# Altium schematic sheet RF_Front-End2.SchDoc
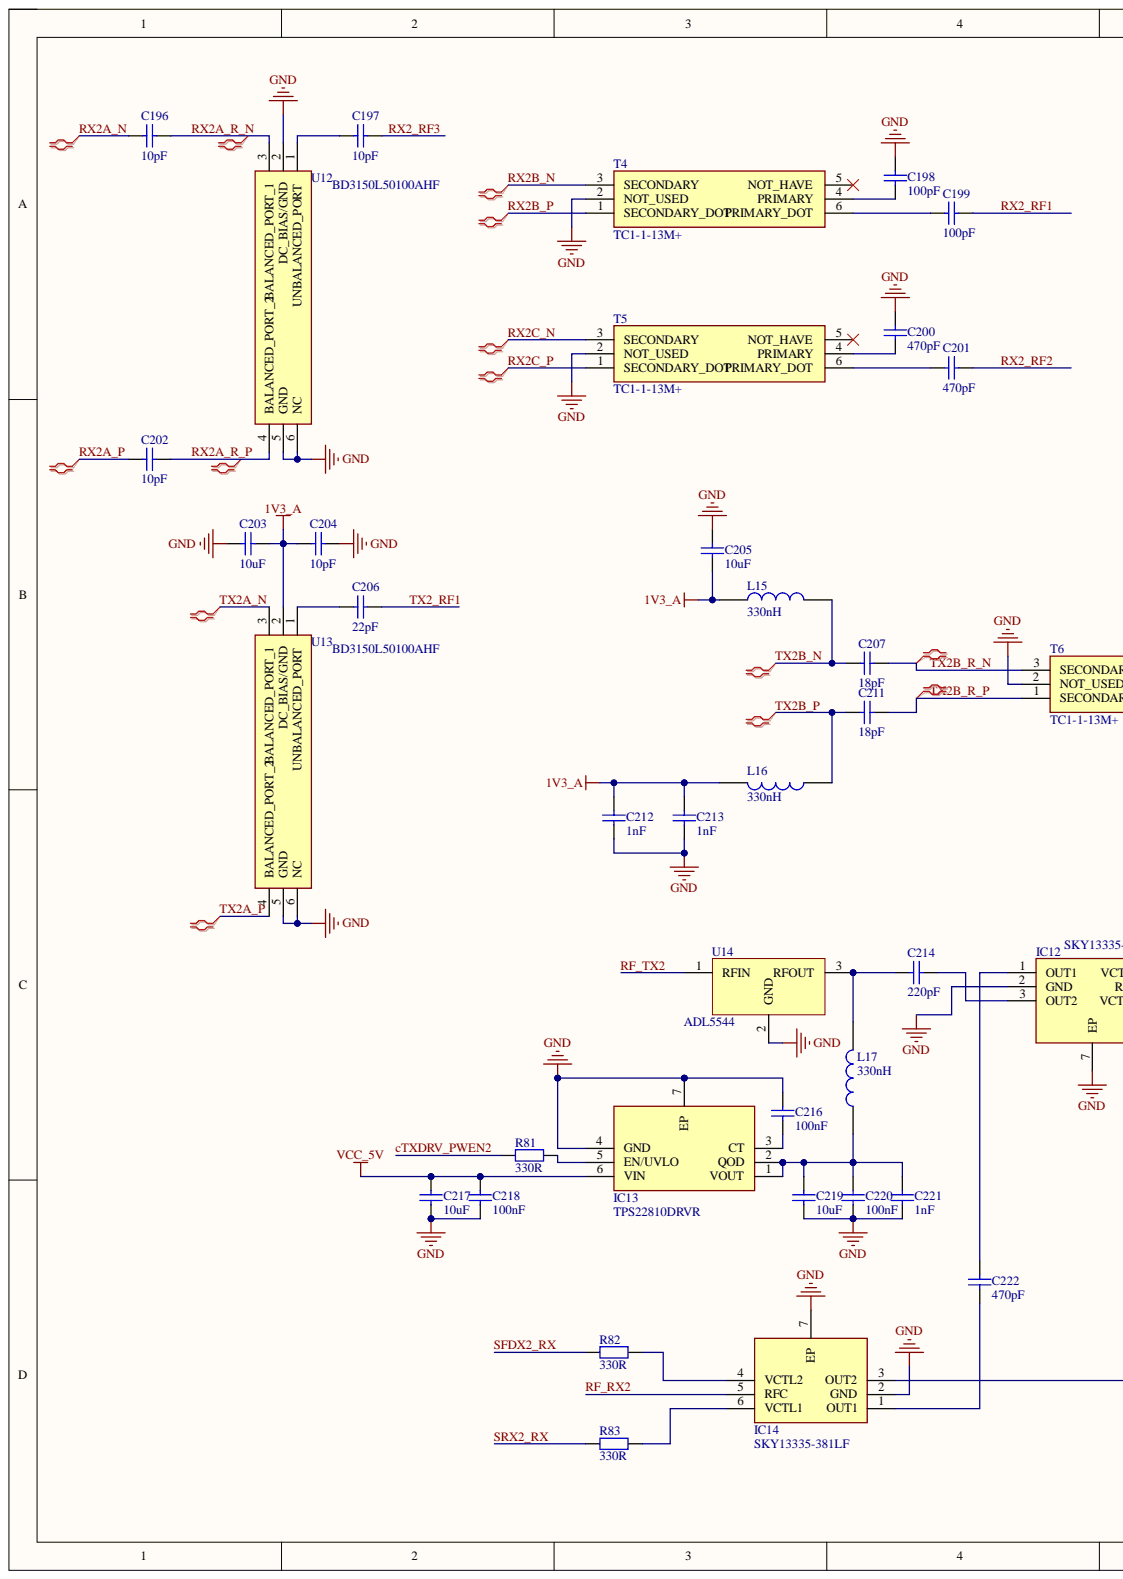
[diagram p0: RF_Front-End2.SchDoc, left]
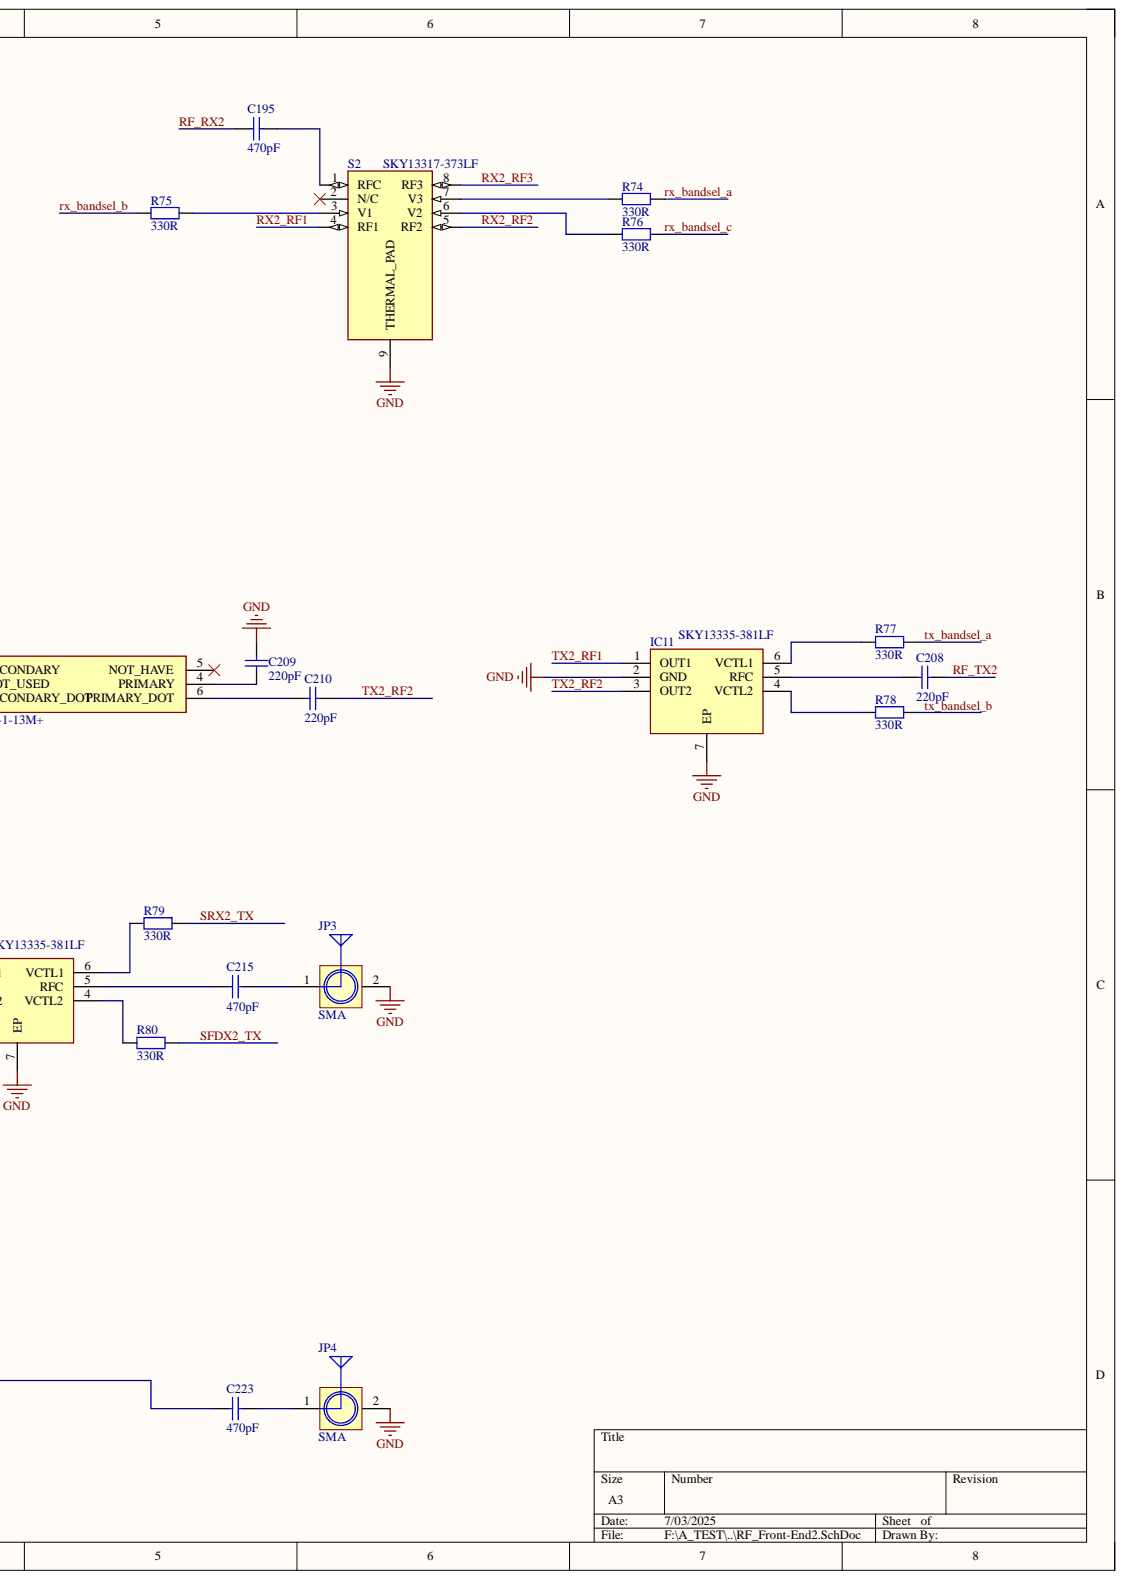
[diagram p1: RF_Front-End2.SchDoc, right]

## Parts
C195  470pF  [C 0402_L]
C196  10pF  [C 0402_L]
C197  10pF  [C 0402_L]
C198  100pF  [C 0402_L]
C199  100pF  [C 0402_L]
C200  470pF  [C 0402_L]
C201  470pF  [C 0402_L]
C202  10pF  [C 0402_L]
C203  10uF  [C 0402_L]
C204  10pF  [C 0402_L]
C205  10uF  [C 0402_L]
C206  22pF  [C 0402_L]
C207  18pF  [C 0402_L]
C208  220pF  [C 0402_L]
C209  220pF  [C 0402_L]
C210  220pF  [C 0402_L]
C211  18pF  [C 0402_L]
C212  1nF  [C 0402_L]
C213  1nF  [C 0402_L]
C214  220pF  [C 0402_L]
C215  470pF  [C 0402_L]
C216  100nF  [C 0402_L]
C217  10uF  [C 0603_L]
C218  100nF  [C 0402_L]
C219  10uF  [C 0603_L]
C220  100nF  [C 0402_L]
C221  1nF  [C 0402_L]
C222  470pF  [C 0402_L]
C223  470pF  [C 0402_L]
IC11  SKY13335-381LF  [SON50P200X150X50-7N]
IC12  SKY13335-381LF  [SON50P200X150X50-7N]
IC13  TPS22810DRVR  [SON65P200X200X80-7N]
IC14  SKY13335-381LF  [SON50P200X150X50-7N]
JP3  SMA  [SMA-KE - 0.5mm]
JP4  SMA  [SMA-KE - 0.5mm]
L15  330nH  [L 0402]
L16  330nH  [L 0402]
L17  330nH  [L 0603]
R74  330R  [R 0402_L]
R75  330R  [R 0402_L]
R76  330R  [R 0402_L]
R77  330R  [R 0402_L]
R78  330R  [R 0402_L]
R79  330R  [R 0402_L]
R80  330R  [R 0402_L]
R81  330R  [R 0402_L]
R82  330R  [R 0402_L]
R83  330R  [R 0402_L]
S2  SKY13317-373LF  [SON40P155X155X50-9N]
T4  TC1-1-13M+  [TC414]
T5  TC1-1-13M+  [TC414]
T6  TC1-1-13M+  [TC414]
U12  BD3150L50100AHF  [BD3150L50100AHF]
U13  BD3150L50100AHF  [BD3150L50100AHF]
U14  ADL5544  [SOT89]

## Nets
1V3_A: C203.1 C204.2 C205.1 C212.1 C213.1 L15.1 L16.2 U13.2(DC_BIAS/GND)
GND: C198.1 C200.1 C203.2 C204.1 C205.2 C209.1 C212.2 C213.2 C216.2 C217.1 C218.1 C219.1 C220.1 C221.1 IC11.2(GND) IC11.7(EP) IC12.2(GND) IC12.7(EP) IC13.4(GND) IC13.7(EP) IC14.2(GND) IC14.7(EP) JP3.2 JP4.2 S2.9(THERMAL_PAD) T4.2(NOT_USED) T5.2(NOT_USED) T6.2(NOT_USED) U12.2(DC_BIAS/GND) U12.5(GND) U12.6(NC) U13.5(GND) U13.6(NC) U14.2
VCC_5V: C217.2 C218.2 IC13.6(VIN)
cTXDRV_PWEN2: R81.1
RF_RX2: C195.1 IC14.5(RFC)
RF_TX2: C208.1 U14.1
RX2_RF1: C199.2 S2.4(RF1)
RX2_RF2: C201.2 S2.5(RF2)
RX2_RF3: C197.1 S2.8(RF3)
RX2A_N: C196.2
RX2A_P: C202.2
RX2A_R_N: C196.1 U12.3(BALANCED_PORT_1)
RX2A_R_P: C202.1 U12.4(BALANCED_PORT_2)
RX2B_N: T4.3(SECONDARY)
RX2B_P: T4.1(SECONDARY_DOT)
RX2C_N: T5.3(SECONDARY)
RX2C_P: T5.1(SECONDARY_DOT)
rx_bandsel_a: R74.2
rx_bandsel_b: R75.1
rx_bandsel_c: R76.2
SFDX2_RX: R82.1
SFDX2_TX: R80.2
SRX2_RX: R83.1
SRX2_TX: R79.2
TX2_RF1: C206.1 IC11.1(OUT1)
TX2_RF2: C210.2 IC11.3(OUT2)
TX2A_N: U13.3(BALANCED_PORT_1)
TX2A_P: U13.4(BALANCED_PORT_2)
TX2B_N: C207.1 L15.2
TX2B_P: C211.1 L16.1
TX2B_R_N: C207.2 T6.3(SECONDARY)
TX2B_R_P: C211.2 T6.1(SECONDARY_DOT)
tx_bandsel_a: R77.2
tx_bandsel_b: R78.2
NetC195_2: C195.2 S2.1(RFC)
NetC197_2: C197.2 U12.1(UNBALANCED_PORT)
NetC198_2: C198.2 T4.4(PRIMARY)
NetC199_1: C199.1 T4.6(PRIMARY_DOT)
NetC200_2: C200.2 T5.4(PRIMARY)
NetC201_1: C201.1 T5.6(PRIMARY_DOT)
NetC206_2: C206.2 U13.1(UNBALANCED_PORT)
NetC208_2: C208.2 IC11.5(RFC)
NetC209_2: C209.2 T6.4(PRIMARY)
NetC210_1: C210.1 T6.6(PRIMARY_DOT)
NetC214_1: C214.1 IC12.3(OUT2)
NetC214_2: C214.2 L17.2 U14.3
NetC215_1: C215.1 JP3.1
NetC215_2: C215.2 IC12.5(RFC)
NetC216_1: C216.1 IC13.3(CT)
NetC219_2: C219.2 C220.2 C221.2 IC13.1(VOUT) IC13.2(QOD) L17.1
NetC222_1: C222.1 IC12.1(OUT1)
NetC222_2: C222.2 IC14.1(OUT1)
NetC223_1: C223.1 JP4.1
NetC223_2: C223.2 IC14.3(OUT2)
NetIC11_4: IC11.4(VCTL2) R78.1
NetIC11_6: IC11.6(VCTL1) R77.1
NetIC12_4: IC12.4(VCTL2) R80.1
NetIC12_6: IC12.6(VCTL1) R79.1
NetIC13_5: IC13.5(EN/UVLO) R81.2
NetIC14_4: IC14.4(VCTL2) R82.2
NetIC14_6: IC14.6(VCTL1) R83.2
NetR74_1: R74.1 S2.7(V3)
NetR75_2: R75.2 S2.3(V1)
NetR76_1: R76.1 S2.6(V2)

Not connected: S2 2(N/C); T4 5(NOT_HAVE); T5 5(NOT_HAVE); T6 5(NOT_HAVE)

## Library data of the parts
C195-C223 = Cap: 贴片电容; Component Kind: Standard; Library Name: A_Schlib.SchLib
  C195 C200 C201 C215 C222 C223: value: 470pF
  C196 C197 C202 C204: value: 10pF
  C198 C199: value: 100pF
  C203 C205 C217 C219: value: 10uF
  C206: value: 22pF
  C207 C211: value: 18pF
  C208-C210 C214: value: 220pF
  C212 C213 C221: value: 1nF
  C216 C218 C220: value: 100nF
IC11 IC12 IC14 = SKY13335-381LF: Integrated Circuit; Manufacturer_Name: Skyworks; Component Kind: Standard; Datasheet Link: https://eu.mouser.com/datasheet/2/472/SKY13335_381LF_201093D-1524822.pdf; Height: 0.5mm; Library Name: SamacSys.SchLib; Manufacturer_Part_Number: SKY13335-381LF; Mouser Part Number: 873-SKY13335-381LF; Mouser Price/Stock: https://www.mouser.co.uk/ProductDetail/Skyworks-Solutions-Inc/SKY13335-381LF?qs=WMHGlxXAKT8o8eJW4DHjzg%3D%3D
IC13 = TPS22810DRVR: Integrated Circuit; Manufacturer_Name: Texas Instruments; Arrow Part Number: TPS22810DRVR; Arrow Price/Stock: https://www.arrow.com/en/products/tps22810drvr/texas-instruments?region=nac; Component Kind: Standard; Datasheet Link: http://www.ti.com/lit/gpn/tps22810; Height: 0.8mm; Library Name: SamacSys.SchLib; Manufacturer_Part_Number: TPS22810DRVR; Mouser Part Number: 595-TPS22810DRVR; Mouser Price/Stock: https://www.mouser.co.uk/ProductDetail/Texas-Instruments/TPS22810DRVR?qs=5aG0NVq1C4wkfnc1%252B6LezA%3D%3D
JP3 JP4 = SMA: SMA-2.4G射频天线接口; 版权归属: 源创客; BOM名称: SMA-2.4G射频天线接口; Component Kind: Standard; Library Name: A_Schlib.SchLib; 学习资源: www.ooocke.com
L15-L17 = 电感: 包含功率; Component Kind: Standard; Library Name: A_Schlib.SchLib
R74-R83 = Res: 通用; Component Kind: Standard; Library Name: A_Schlib.SchLib; value: 330R
S2 = SKY13317-373LF: Switch; Manufacturer_Name: Skyworks; Arrow Part Number: SKY13317-373LF; Arrow Price/Stock: https://www.arrow.com/en/products/sky13317-373lf/skyworks-solutions?utm_currency=USD&region=nac; Component Kind: Standard; Datasheet Link: https://componentsearchengine.com/Datasheets/1/SKY13317-373LF.pdf; Height: 0.5mm; Library Name: SamacSys.SchLib; Manufacturer_Part_Number: SKY13317-373LF; Mouser Part Number: 873-SKY13317-373LF; Mouser Price/Stock: https://www.mouser.co.uk/ProductDetail/Skyworks-Solutions-Inc/SKY13317-373LF?qs=WMHGlxXAKT%2FS6%2Fi0J8S9Fw%3D%3D
T4-T6 = TC1-1-13M+: Transformer; Manufacturer_Name: Mini-Circuits; Component Kind: Standard; Datasheet Link: https://datasheet.datasheetarchive.com/originals/distributors/Datasheets-28/DSA-546322.pdf; Height: 4.06mm; Library Name: SamacSys.SchLib; Manufacturer_Part_Number: TC1-1-13M+; Mouser Part Number: 139-TC1-1-13M; Mouser Price/Stock: https://www.mouser.co.uk/ProductDetail/Mini-Circuits/TC1-1-13M%2b?qs=xZ%2FP%252Ba9zWqYek%252BZGAF2fWg%3D%3D
U12 U13 = BD3150L50100AHF: Undefined or Miscellaneous; Manufacturer_Name: ANAREN; Component Kind: Standard; Datasheet Link: https://cdn.ttm.com/repository/products/wireless-xinger/balun-transformers/BD3150L50100AHF/BD3150L50100AHF.pdf; Height: 0.74mm; Manufacturer_Part_Number: BD3150L50100AHF; Mouser Part Number: 620-BD3150L50100AHF; Mouser Price/Stock: https://www.mouser.co.uk/ProductDetail/Anaren/BD3150L50100AHF?qs=voMMqUKR%252ByDvMcYv7w2PZg%3D%3D
U14 = PGA-102+: Component Kind: Standard; Library Name: A_Schlib.SchLib
